# Altium schematic sheet Teensy Connections.SchDoc
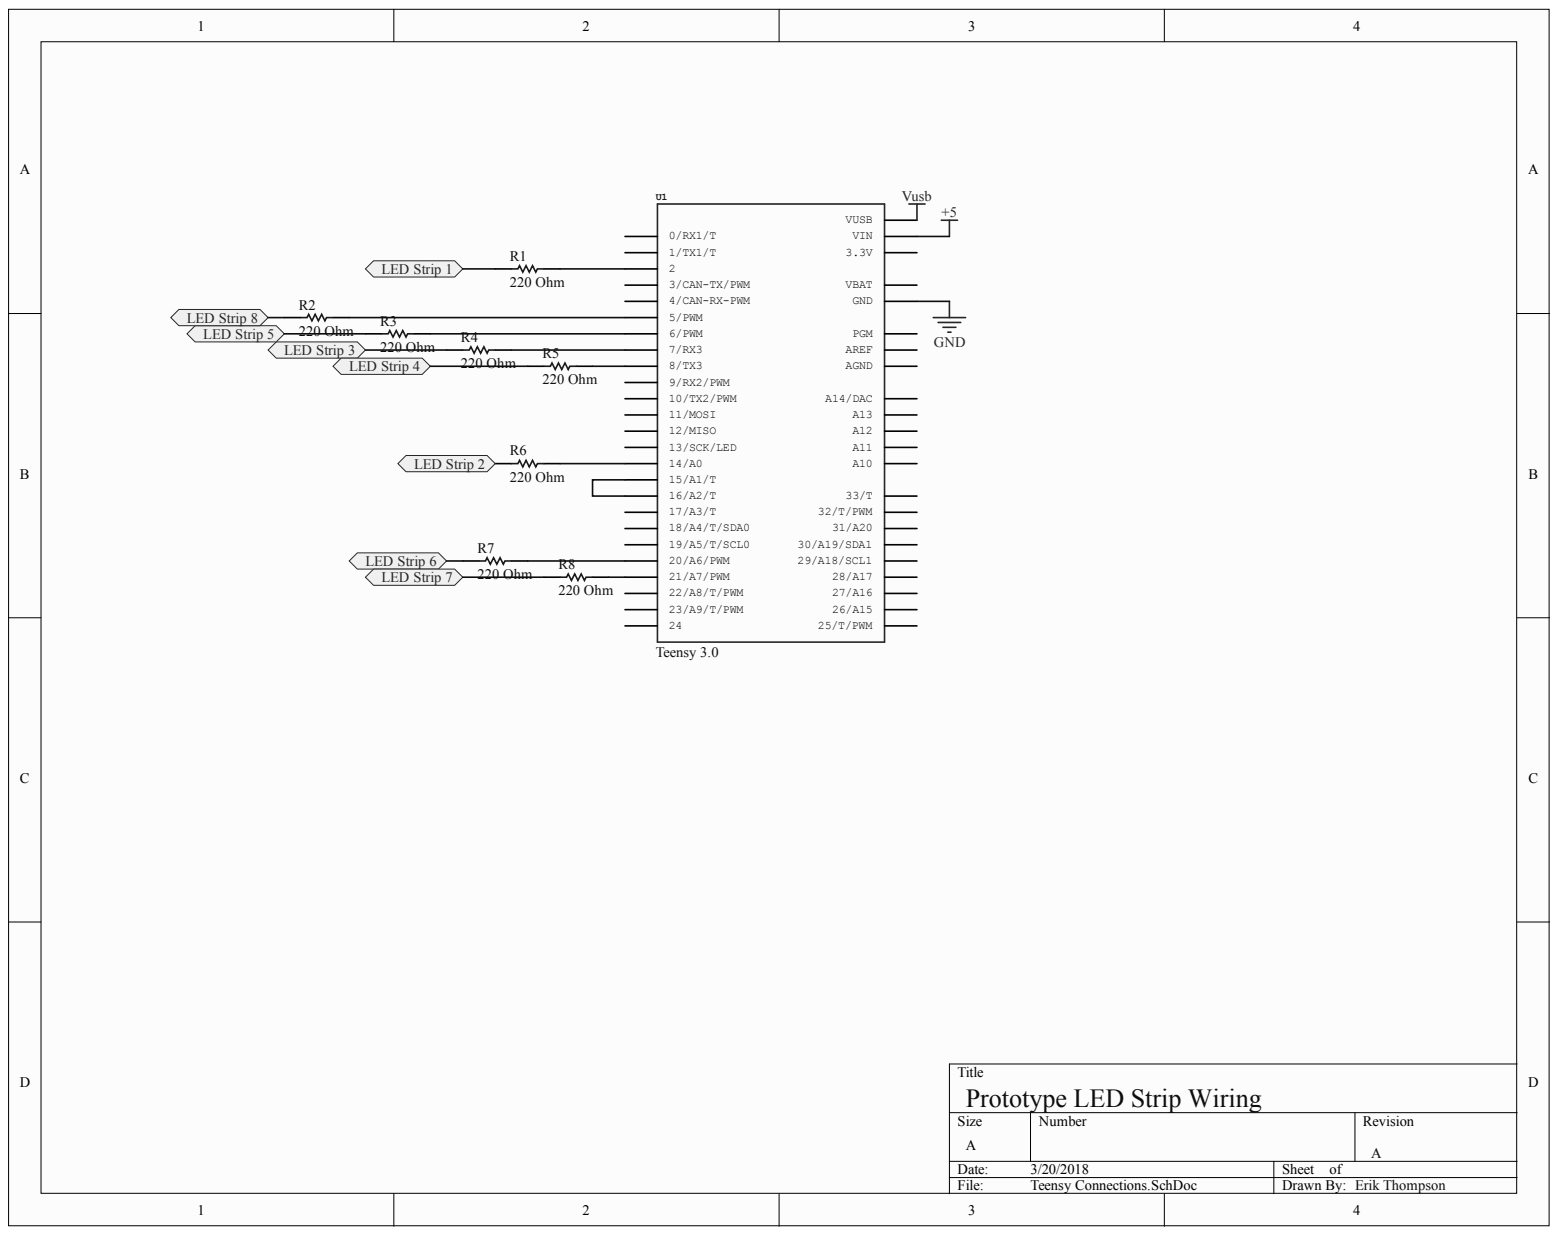
[diagram p0: Teensy Connections.SchDoc]

## Parts
R1  220 Ohm
R2  220 Ohm
R3  220 Ohm
R4  220 Ohm
R5  220 Ohm
R6  220 Ohm
R7  220 Ohm
R8  220 Ohm
U1  Teensy 3.0  [PCB-6h9hx89n0og4ty06iag5-1]

## Ports
LED STRIP 1, LED STRIP 2, LED STRIP 3, LED STRIP 4, LED STRIP 5, LED STRIP 6, LED STRIP 7, LED STRIP 8

## Nets
3.3V: U1.3.3V1
+5: U1.VIN
GND: U1.GND U1.GND1
VUSB: U1.VUSB
NetR1_1: R1.1 ; port LED STRIP 1
NetR1_2: R1.2 U1.2
NetR2_1: R2.1 ; port LED STRIP 8
NetR2_2: R2.2 U1.5(5/PWM)
NetR3_1: R3.1 ; port LED STRIP 5
NetR3_2: R3.2 U1.6(6/PWM)
NetR4_1: R4.1 ; port LED STRIP 3
NetR4_2: R4.2 U1.7(7/RX3)
NetR5_1: R5.1 ; port LED STRIP 4
NetR5_2: R5.2 U1.8(8/TX3)
NetR6_1: R6.1 ; port LED STRIP 2
NetR6_2: R6.2 U1.14/A0
NetR7_1: R7.1 ; port LED STRIP 6
NetR7_2: R7.2 U1.20/A6(20/A6/PWM)
NetR8_1: R8.1 ; port LED STRIP 7
NetR8_2: R8.2 U1.21/A7(21/A7/PWM)
NetU1_15/A1: U1.15/A1(15/A1/T) U1.16/A2(16/A2/T)

Not connected: U1 0(0/RX1/T) 1(1/TX1/T) 3(3/CAN-TX/PWM) 3.3V 4(4/CAN-RX-PWM) 9(9/RX2/PWM) 10(10/TX2/PWM) 11(11/MOSI) 12(12/MISO) 13(13/SCK/LED) 17/A3(17/A3/T) 18/A4(18/A4/T/SDA0) 19/A5(19/A5/T/SCL0) 22/A8(22/A8/T/PWM) 23/A9(23/A9/T/PWM) 24 25(25/T/PWM) 26(26/A15) 27(27/A16) 28(28/A17) 29(29/A18/SCL1) 30(30/A19/SDA1) 31(31/A20) 32(32/T/PWM) 33(33/T) A10 A11 A12 A13 A14(A14/DAC) AGND AREF PGM VBAT

## Library data of the parts
R1-R8 = RP73PF2A33K2BTDF: Surface Mount Thin Film Resistor, RP73 Series, 33.2 kohm, 250 mW, - 0.1%, 150 V; Power Rating: 250 mW; Resistance: 33.2 kΩ; Voltage Rating (DC): 150 V; Case/Package: 0805, 2012; Component Kind: Standard; Library Name: Octopart library; Lifecycle Status: Not Listed by Manufacturer; Manufacturer Part Number: RP73PF2A33K2BTDF; Mounting Style: Surface Mount; OctopartID: 32c1f97ed310ed06; Octopart URL: http://octopart.com/rp73pf2a33k2btdf-te+connectivity-45466807; Packaging: Cut Tape (CT); REACH SVHC Compliance: No SVHC; Resistance Tolerance: ±0.1%; RoHS: Compliant
U1 = DEV-13736: Dev Teensy 3.2 13736; Component Kind: Standard; Library Name: Octopart library; Manufacturer Part Number: DEV-13736; OctopartID: cc4306b2fccc1384; Octopart URL: http://octopart.com/dev-13736-sparkfun-66786793
